# Altium schematic sheet POWER_USB.SchDoc
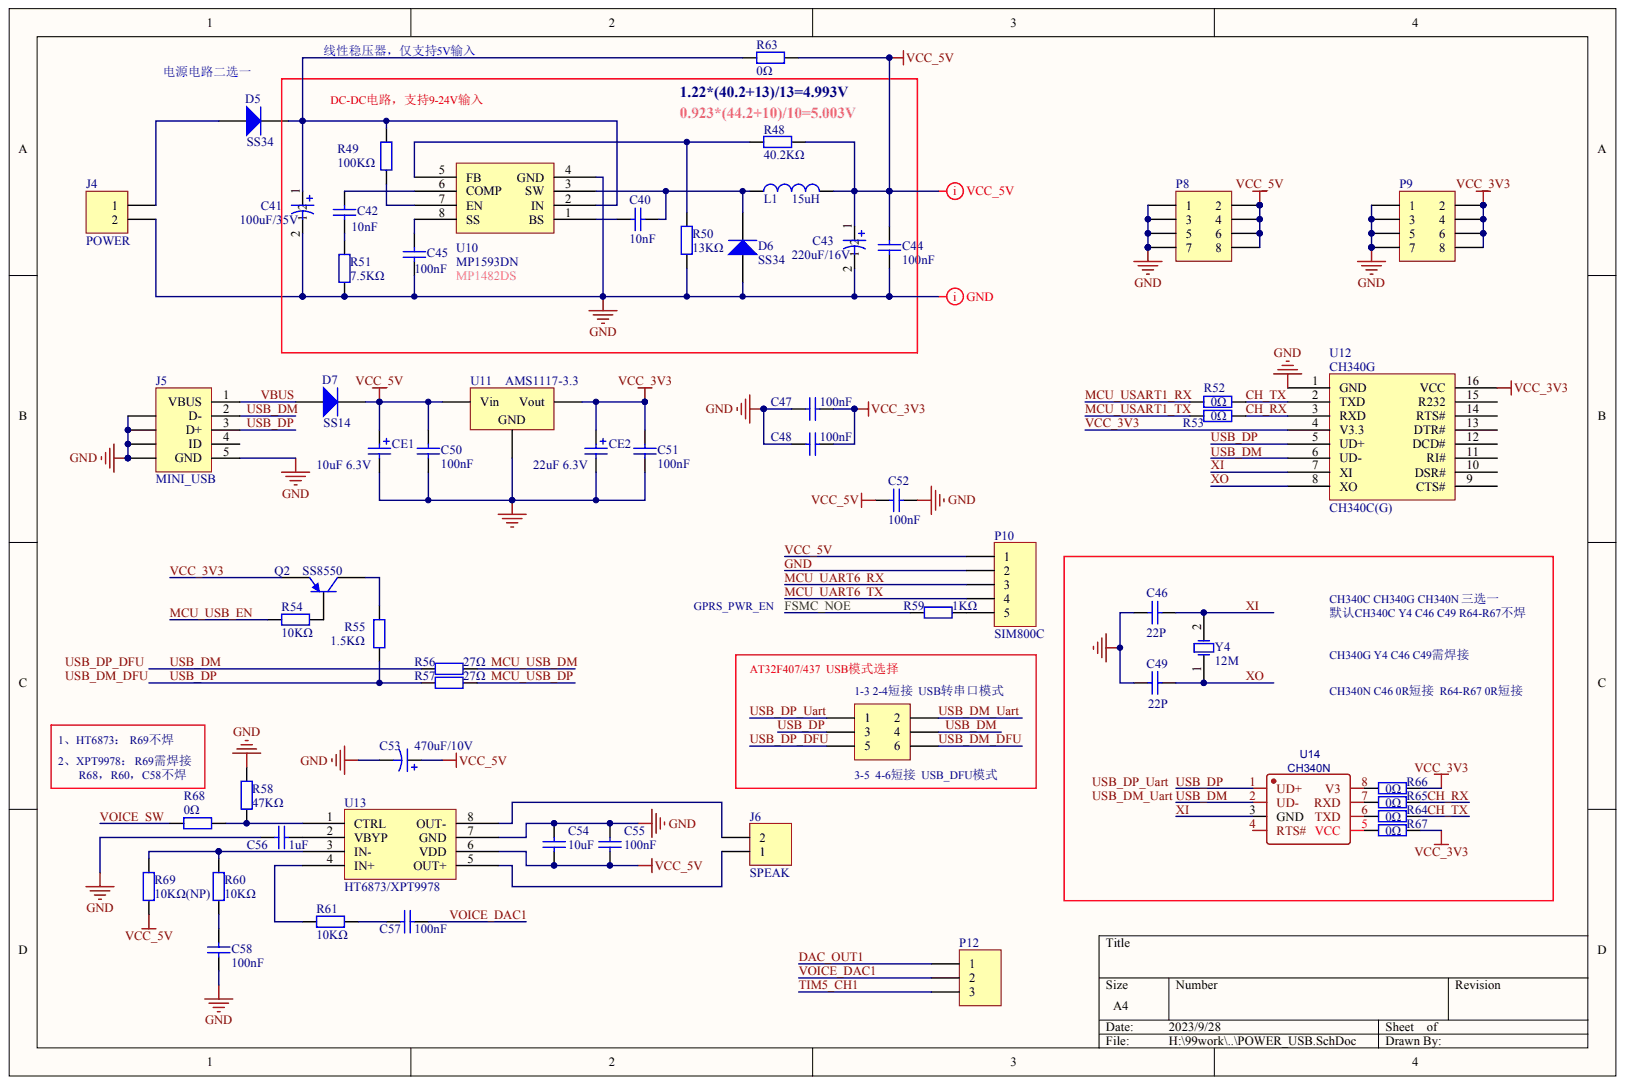
[diagram p0: POWER_USB.SchDoc]

## Parts
C40  10nF
C41  100uF/35V
C42  10nF
C43  220uF/16V
C44  100nF
C45  100nF
C46  22P
C47  100nF
C48  100nF
C49  22P
C50  100nF
C51  100nF
C52  100nF
C53  470uF/10V
C54  10uF
C55  100nF
C56  1uF
C57  100nF
C58  100nF
CE1  10uF 6.3V
CE2  22uF 6.3V
D5  SS34
D6  SS34
D7  SS14
J4  POWER
J5  MINI_USB
J6  SPEAK
L1  15uH
P8  (no value)
P9  (no value)
P10  SIM800C
P12  (no value)
Q2  SS8550
R48  40.2KΩ
R49  100KΩ
R50  13KΩ
R51  7.5KΩ
R52  0Ω
R53  0Ω
R54  10KΩ
R55  1.5KΩ
R56  27Ω
R57  27Ω
R58  47KΩ
R59  1KΩ
R60  10KΩ
R61  10KΩ
R63  0Ω
R64  0Ω
R65  0Ω
R66  0Ω
R67  0Ω
R68  0Ω
R69  10KΩ(NP)
U10  MP1593DN
U11  AMS1117-3.3
U12  CH340C(G)
U13  HT6873/XPT9978
U14  CH340N
Y4  12M
  (no value)

## Nets
CH_RX: R53.1 R65.1 U12.3(RXD)
CH_TX: R52.1 R64.1 U12.2(TXD)
DAC_OUT1: P12.1
FSMC_NOE: R59.2
GND: C41.2 C43.2 C44.1 C45.2 C46.1 C47.2 C48.2 C49.1 C50.1 C51.1 C52.1 C53.2 C54.2 C55.2 C56.1 C58.1 CE1.2 CE2.2 D6.1 J4.2 J5.5(GND) J5.6 J5.7 J5.8 J5.9 P8.1 P8.3 P8.5 P8.7 P9.1 P9.3 P9.5 P9.7 P10.2 R50.2 R51.1 R58.2 U10.4(GND) U11.1 U12.1(GND) U13.7(GND)
MCU_UART6_RX: P10.3
MCU_UART6_TX: P10.4
MCU_USART1_RX: R52.2
MCU_USART1_TX: R53.2
MCU_USB_DM: R56.2
MCU_USB_DP: R57.2
MCU_USB_EN: R54.2
TIM5_CH1: P12.3
USB_DM: J5.2(D-) R56.1 U12.6(UD-) U14.2(UD-) .4
USB_DM_DFU: .6
USB_DM_UART: .2
USB_DP: J5.3(D+) R55.1 R57.1 U12.5(UD+) U14.1(UD+) .3
USB_DP_DFU: .5
USB_DP_UART: .1
VBUS: D7.1 J5.1(VBUS)
VCC_3V3: C47.1 C48.1 C51.2 CE2.1 P9.2 P9.4 P9.6 P9.8 Q2.2 R66.1 R67.1 U11.2 U12.4(V3.3) U12.16(VCC)
VCC_5V: C43.1 C44.2 C50.2 C52.2 C53.1 C54.1 C55.1 CE1.1 D7.2 L1.2 P8.2 P8.4 P8.6 P8.8 P10.1 R48.1 R63.1 R69.1 U11.3 U13.6(VDD)
VOICE_DAC1: C57.2 P12.2
VOICE_SW: R68.2
XI: C46.2 U12.7(XI) U14.3(GND) Y4.2
XO: C49.2 U12.8(XO) Y4.1
NetC40_1: C40.1 U10.1(BS)
NetC40_2: C40.2 D6.2 L1.1 U10.3(SW)
NetC41_1: C41.1 D5.2 R49.2 R63.2 U10.2(IN)
NetC42_1: C42.1 R51.2
NetC42_2: C42.2 U10.6(COMP)
NetC45_1: C45.1 U10.8(SS)
NetC56_2: C56.2 U13.2(VBYP)
NetC57_1: C57.1 R61.1
NetC58_2: C58.2 R60.1
NetD5_1: D5.1 J4.1
NetJ6_1: J6.1 U13.5(OUT+)
NetJ6_2: J6.2 U13.8(OUT-)
NetP10_5: P10.5 R59.1
NetQ2_1: Q2.1 R54.1
NetQ2_3: Q2.3 R55.2
NetR48_2: R48.2 R50.1 U10.5(FB)
NetR49_1: R49.1 U10.7(EN)
NetR58_1: R58.1 R68.1 U13.1(CTRL)
NetR60_2: R60.2 R69.2 U13.3(IN-)
NetR61_2: R61.2 U13.4(IN+)
NetR64_2: R64.2 U14.6(TXD)
NetR65_2: R65.2 U14.7(RXD)
NetR66_2: R66.2 U14.8(V3)
NetR67_2: R67.2 U14.5(VCC)
N00189:
N00190:

Not connected: J5 4(ID); U12 9(CTS#) 10(DSR#) 11(RI#) 12(DCD#) 13(DTR#) 14(RTS#) 15(R232); U14 4(RTS#)

## Library data of the parts
C41 C43 C53 = (no symbol name): Value: 10uF; Published: 8-Jun-2000; LatestRevisionDate: 17-Jul-2002; LatestRevisionNote: Re-released for DXP Platform.; PackageReference: RB7.6-15; PackageDescription: Polarized Capacitor (Radial); 2 Leads; Publisher: Altium Limited
L1 = (no symbol name): Code_IEC: 1005; Published: 8-Jun-2000; LatestRevisionDate: 29-May-2009; LatestRevisionNote: IPC-7351 Footprint Added.; PackageReference: 0402-A; PackageDescription: Chip Inductor; Code_JEITA: 0402; Value: 15uH; Publisher: Altium Limited
U14 = (no symbol name): BOM_Manufacturer: WCH(南京沁恒); Contributor: LCSC; BOM_Supplier: LCSC; BOM_Supplier Part: C2977777; BOM_Manufacturer Part: CH340N; BOM_JLCPCB Part Class: Extended Part; spicePre: U; spiceSymbolName: CH340N_C2977777
C40 C42 C44-C52 C54-C58 CE1 CE2 D5-D7 J4-J6 P8-P10 P12 Q2 R48-R61 R63-R69 U10-U13 Y4  = (no symbol name)
  C40 C45 C47 C48 C52: ComponentLink1Description: 供应商链接; ComponentLink1URL: http://www.szlcsc.com/product/details_15331.html; Package: 0603; Supplier: LC; SuppliersPartNumber: C14663
  C42 C44 C50 C51: ComponentLink1Description: 供应商链接; ComponentLink1URL: http://www.szlcsc.com/product/details_24709.html; Package: 0603; Supplier: LC; SuppliersPartNumber: C23969
  C46 C49 C54-C58: Published: 8-Jun-2000; LatestRevisionDate: 17-Jul-2002; LatestRevisionNote: Re-released for DXP Platform.; PackageReference: RAD-0.3; PackageDescription: Radial Cap, Thru-Hole; 2 Leads; 0.3 in Pin Spacing
  CE1 CE2: ComponentLink1Description: 供应商链接; ComponentLink1URL: http://www.szlcsc.com/product/details_16815.html; Package: 3528; Supplier: LC; SuppliersPartNumber: C16133
  D5 D7: ComponentLink1Description: 供应商链接; ComponentLink1URL: http://www.szlcsc.com/product/details_10338.html; Package: LL-34; Supplier: LC; SuppliersPartNumber: C9808
  D6: ComponentLink1Description: 供应商链接; ComponentLink1URL: http://www.szlcsc.com/product/details_36698.html; Package: SMC(DO-214AB); Supplier: LC; SuppliersPartNumber: C35722
  J4 J6 P8-P10 P12 : LatestRevisionDate: 17-Jul-2002; LatestRevisionNote: Re-released for DXP Platform.
  Q2: ComponentLink1Description: 供应商链接; ComponentLink1URL: http://www.szlcsc.com/product/details_9037.html; Package: SOT-23; Supplier: LC; SuppliersPartNumber: C8542
  R48-R51 R63: ComponentLink1Description: 供应商链接; ComponentLink1URL: http://www.szlcsc.com/product/details_21904.html; Package: 0603; Supplier: LC; SuppliersPartNumber: C21190
  R52 R53 R59 R64-R67: ComponentLink1Description: 供应商链接; ComponentLink1URL: http://www.szlcsc.com/product/details_26547.html; Package: 0603; Supplier: LC; SuppliersPartNumber: C25804
  R54-R58 R60 R61 R68 R69: ComponentLink1Description: 供应商链接; ComponentLink1URL: http://www.szlcsc.com/product/details_21903.html; Package: 0603; Supplier: LC; SuppliersPartNumber: C21189
  U10: ComponentLink1Description: 供应商链接; ComponentLink1URL: http://www.szlcsc.com/product/details_15539.html; Package: SOIC-8_150mil; Supplier: LC; SuppliersPartNumber: C14867
  U11: ComponentLink1Description: 供应商链接; ComponentLink1URL: http://www.szlcsc.com/product/details_6652.html; Package: SOT-223; Supplier: LC; SuppliersPartNumber: C6186
  U12: ComponentLink1Description: 供应商链接; ComponentLink1URL: http://www.szlcsc.com/product/details_14927.html; Package: SOIC-16_150mil; Supplier: LC; SuppliersPartNumber: C14267
  Y4: Published: 8-Jun-2000; LatestRevisionDate: 17-Jul-2002; LatestRevisionNote: Re-released for DXP Platform.; PackageReference: R38; PackageDescription: Crystal, Thru-Hole; 2 Leads; Body 3.1 x 8.2 mm (Dia.xH)
  C46 C49 C54-C58 J4 J6 P8-P10 P12 Y4 : Publisher: Altium Limited
